# Altium schematic sheet LDO-LM1117.SchDoc
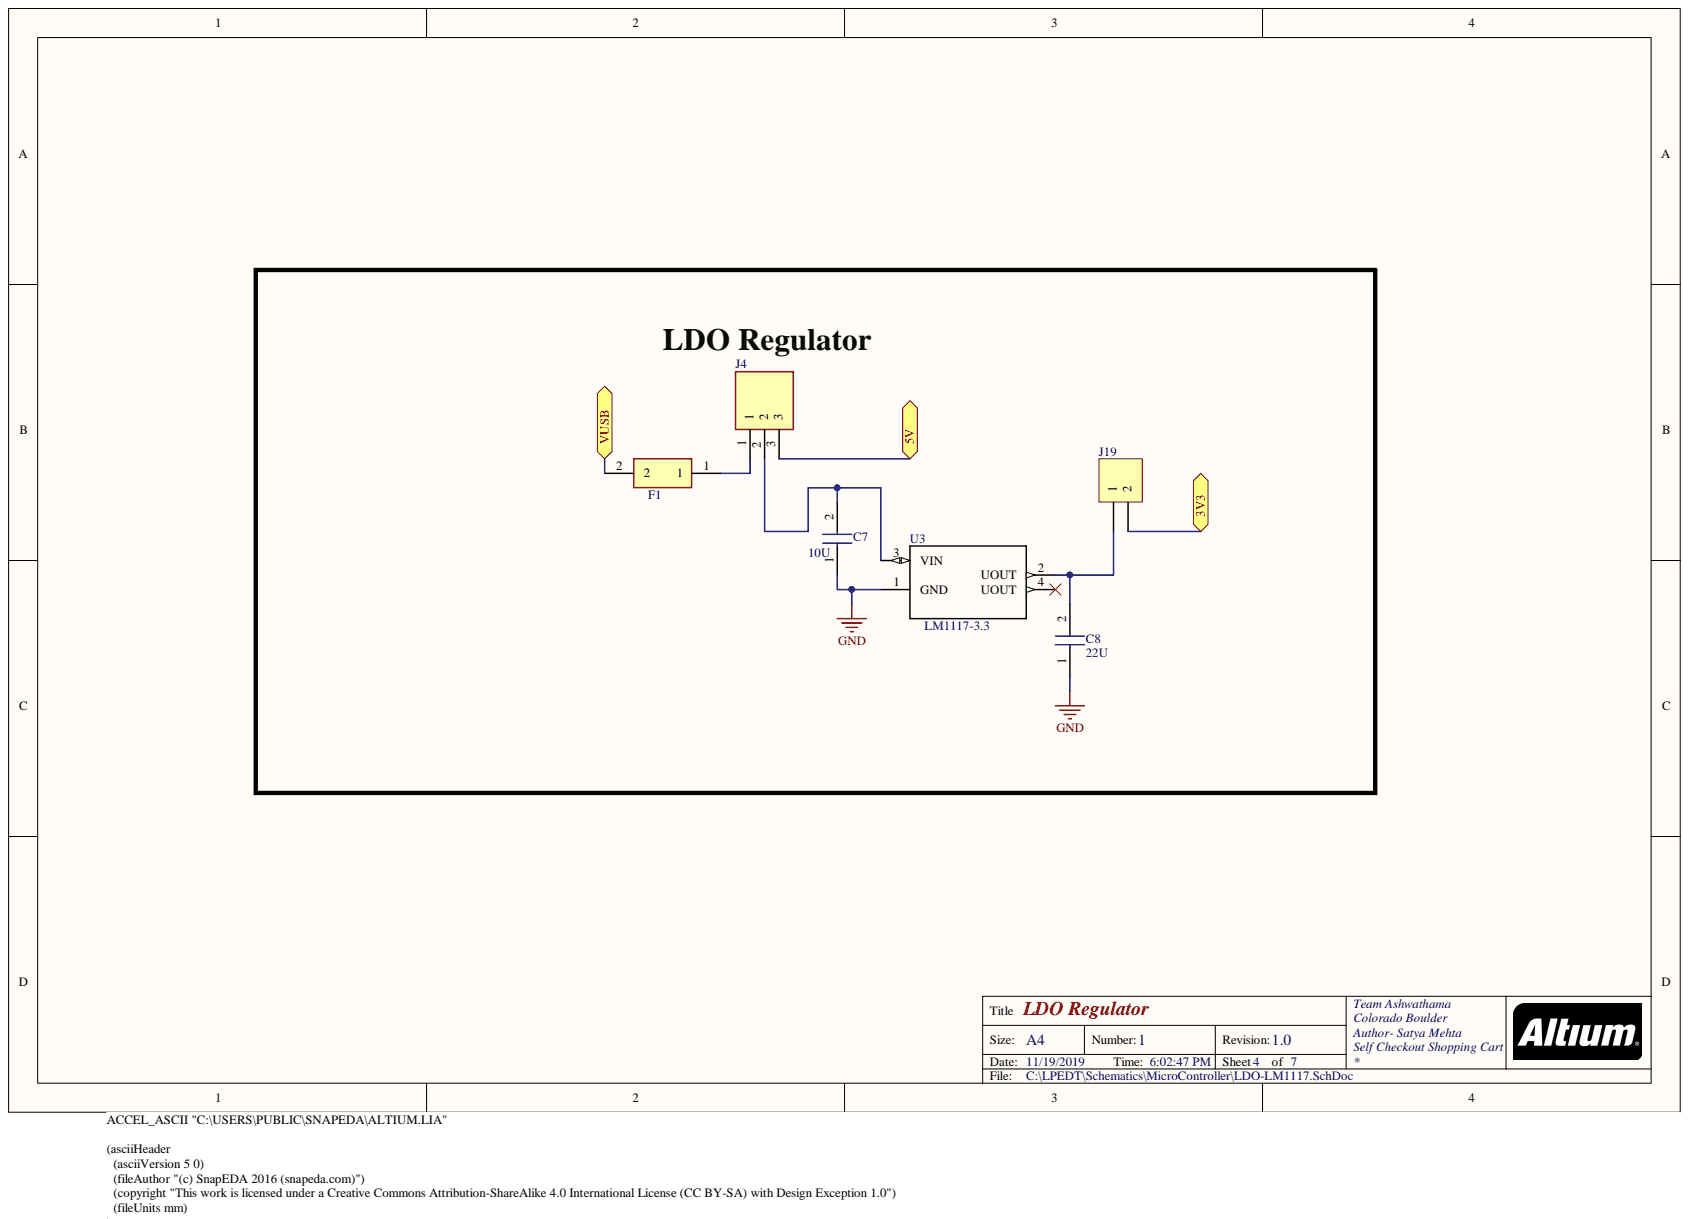
[diagram p0: LDO-LM1117.SchDoc]

## Parts
C7  value 10U  [CAPC6153X300N]  (hidden comment: KCM55LR71E156KH01K)
C8  value 22U  [CAPC3225X270N]  (hidden comment: GRM32ER71C226KEA8L)
F1  MF-MSMF050-2  [FUSC4632X85N]  (value not drawn)
J4  61900311121  [SHDR3W64P0X254_1X3_766X580X1175P]  (value not drawn)
J19  Header 2  [HDR1X2]  (value not drawn)
U3  LM1117-3.3  [*SOT-223]

## Ports
3V3, 5V, VUSB

## Nets
GND: C7.1 C8.1 U3.1(GND)
3V3: J19.2 ; port 3V3
5V: J4.3 ; port 5V
VUSB: F1.2 ; port VUSB
NetC7_2: C7.2 J4.2 U3.3(VIN)
NetC8_2: C8.2 J19.1 U3.2(UOUT)
NetF1_1: F1.1 J4.1

Not connected: U3 4(UOUT)

## Library data of the parts
C7 = KCM55LR71E156KH01K: Capacitor; Manufacturer_Name: Murata Electronics; Component Kind: Standard; Datasheet Link: https://psearch.en.murata.com/capacitor/product/KCM55LR71E156KH01%23.html; Height: 3mm; Manufacturer_Part_Number: KCM55LR71E156KH01K; Mouser Part Number: 81-KCM55LR71E156KH1K; Mouser Price/Stock: https://www.mouser.com/ProductDetail/Murata-Electronics/KCM55LR71E156KH01K?qs=%2Fha2pyFadujTVicYMwxGf6al2IEnlbtrqh1JmJOdYSsKFh9aAuQRmXZk846chQr1
C8 = GRM32ER71C226KEA8L: Capacitor; Manufacturer_Name: Murata Electronics; Component Kind: Standard; Datasheet Link: http://psearch.en.murata.com/capacitor/product/GRM32ER71E226KE15%23.pdf; Height: 2.7mm; Manufacturer_Part_Number: GRM32ER71C226KEA8L; Mouser Part Number: 81-GRM32ER71C226KEAL; Mouser Price/Stock: https://www.mouser.com/Search/Refine.aspx?Keyword=81-GRM32ER71C226KEAL
F1 = MF-MSMF050-2: Fuse; Manufacturer_Name: Bourns; Allied_Number: 70153466; Allied Price/Stock: https://www.alliedelec.com/bourns-mf-msmf050-2/70153466/; Component Kind: Standard; Datasheet Link: https://www.bourns.com/pdfs/mfmsmf.pdf; Height: 0.85mm; Manufacturer_Part_Number: MF-MSMF050-2; Mouser Part Number: 652-MF-MSMF050-2; Mouser Price/Stock: https://www.mouser.com/Search/Refine.aspx?Keyword=652-MF-MSMF050-2; RS Part Number: 6478156P; RS Price/Stock: http://uk.rs-online.com/web/p/products/6478156P
J4 = 61900311121: Connector; Manufacturer_Name: Wurth Elektronik; Component Kind: Standard; Datasheet Link: https://katalog.we-online.de/em/datasheet/61900311121.pdf; Height: 11.75mm; Manufacturer_Part_Number: 61900311121; Mouser Part Number: 710-61900311121; Mouser Price/Stock: https://www.mouser.com/Search/Refine.aspx?Keyword=710-61900311121; RS Part Number: 8281474P; RS Price/Stock: http://uk.rs-online.com/web/p/products/8281474P
J19 = Header 2: Header, 2-Pin; Manufacturer_Name: Global Connector Technology; Manufacturer_Part_Number: BG301-04-A-0540-L-B; Component Kind: Standard; Digi-Key Part Number: 2073-BG301-02-A-0540-L-BCT-ND; Digikey Price/Stock: https://www.digikey.com/product-detail/en/gct/BG301-04-A-0540-L-B/2073-BG301-04-A-0540-L-BCT-ND/9859670?utm_source=snapeda&utm_medium=aggregator&utm_campaign=symbol; Library Name: Miscellaneous Connectors.IntLib; Signal Integrity: Connector
U3 = LM1117-3.3: 3V3 Low Dropout Regulator; Manufacturer_Name: Texas Instruments; Manufacturer_Part_Number: LM1117MPX-3.3/NOPB; Component Kind: Standard; Datasheet Link: http://www.ti.com/lit/ds/symlink/lm1117.pdf; Library Name: LM1117-3.3.SchLib; Mouser Part Number: 926-LM1117MPX3.3NOPB; Mouser Price/Stock: https://www.mouser.com/ProductDetail/Texas-Instruments/LM1117MPX-33-NOPB?qs=X1J7HmVL2ZHRbBIxXi4utg%3D%3D; Package: SOT-223-4 Texas Instruments; Price: None
